# Altium schematic sheet Sheet1.SchDoc(Sheet1)
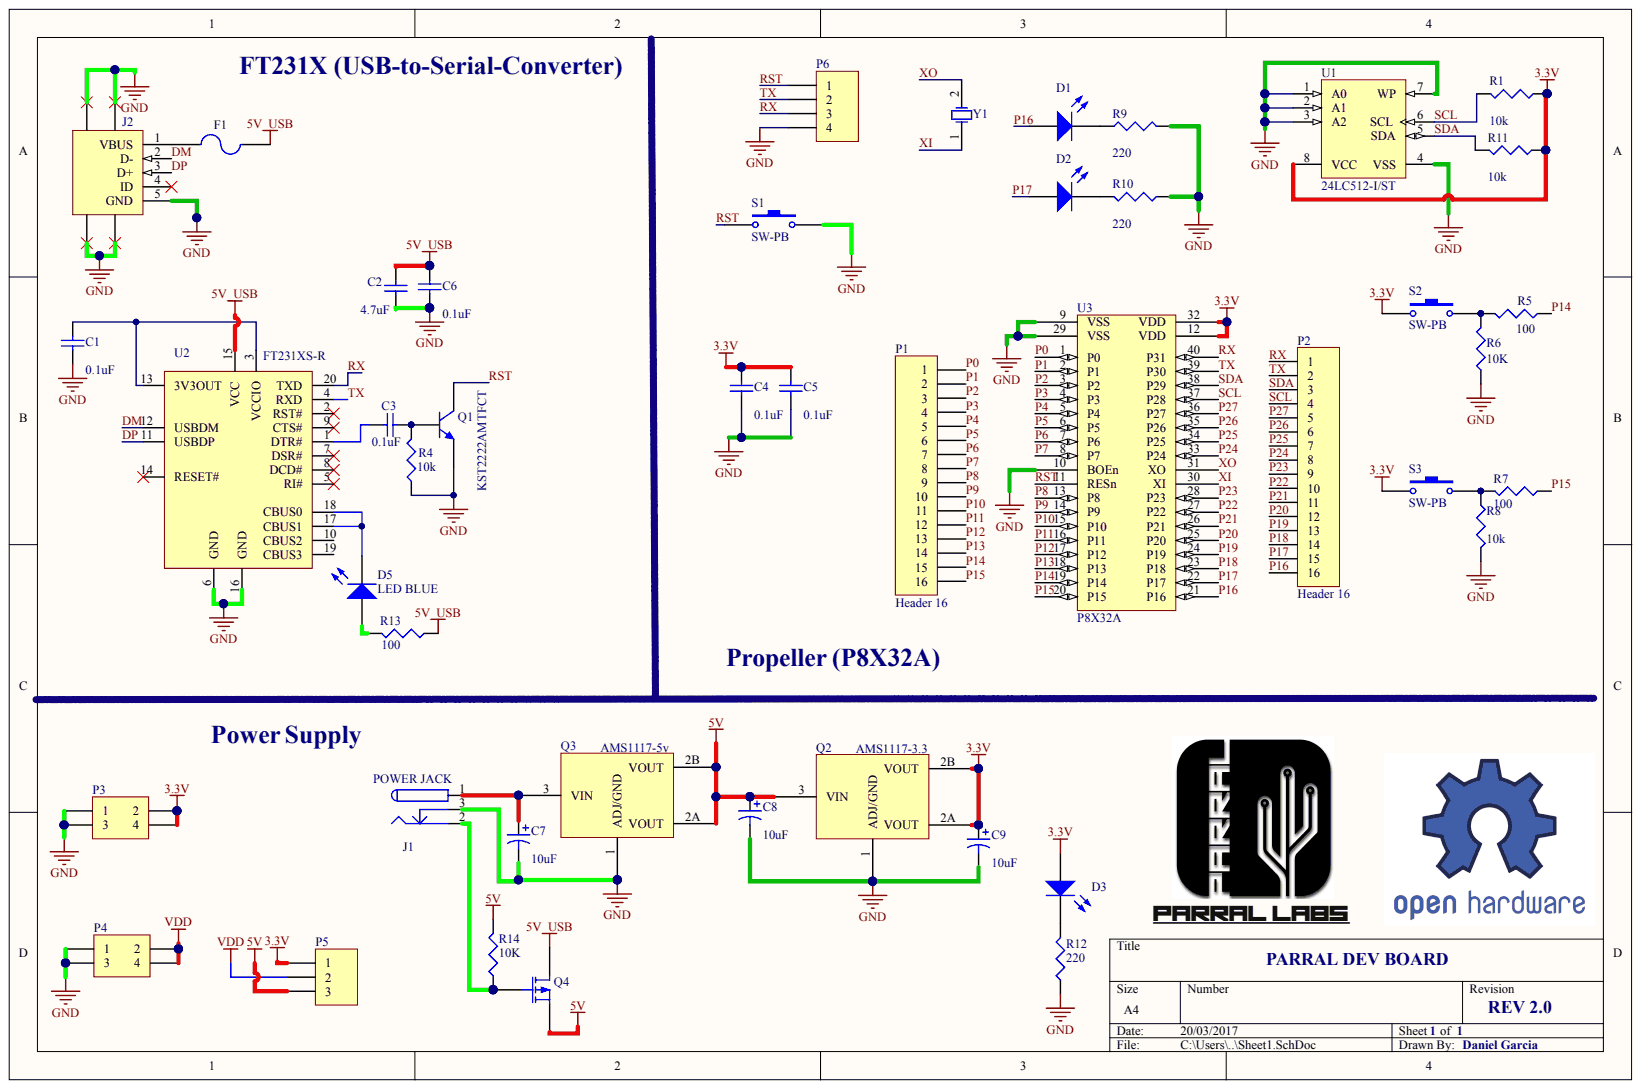
[diagram p0: Sheet1.SchDoc]

## Parts
C1  0.1uF  [0603 - Old1]
C2  4.7uF  [0603 - Old1]
C3  0.1uF  [0603 - Old1]
C4  0.1uF  [0603 - Old1]
C5  0.1uF  [0603 - Old1]
C6  0.1uF  [0603 - Old1]
C7  10uF  [C0805]
C8  10uF  [C0805]
C9  10uF  [C0805]
D1  LED GREEN  [LED-0603]  (value not drawn)
D2  LED BLUE  [LED-0603]  (value not drawn)
D3  LED RED  [LED-0603]  (value not drawn)
D5  LED BLUE  [LED-0603]
F1  PTC  [MF-MSMF050-2]  (value not drawn)
J1  POWER JACK  [PWR JACK]
J2  USB - micro B  [micro B USB 2.0]  (value not drawn)
P1  Header 16  [HDR1X16]
P2  Header 16  [HDR1X16]
P3  Header 2X2  [HDR2X2]  (value not drawn)
P4  Header 2X2  [HDR2X2]  (value not drawn)
P5  Header 3  [HDR1X3]  (value not drawn)
P6  Header 4  [HDR1X4]  (value not drawn)
Q1  KST2222AMTFCT  [SOT-23B_N]
Q2  AMS1117-3.3  Voltage - Output: 3.3V  [SOT223_M]
Q3  AMS1117-5v  Voltage - Output: 5V  [SOT223_M]
Q4  ZXMP6A13FTA  [SOT-23-3]  (value not drawn)
R1  10K  [0603-Resistor]  (value not drawn)
R4  10K  [0603-Resistor]
R5  100  [0603-Resistor]
R6  10K  [0603-Resistor]
R7  100  [0603-Resistor]
R8  10K  [0603-Resistor]
R9  220  [0603-Resistor]
R10  220  [0603-Resistor]
R11  10K  [0603-Resistor]
R12  220  [0603-Resistor]
R13  100  [0603-Resistor]
R14  10K  [0603-Resistor]
S1  SW-PB  [PTS810]
S2  SW-PB  [PTS810]
S3  SW-PB  [PTS810]
U1  24LC512-I/ST  [SOIC-8]
U2  FT231XS-R
U3  P8X32A  [DIP-40]
Y1  XTAL 5MHZ  [XTAL-2]  (value not drawn)

## Nets
3.3V: C4.2 C5.2 C9.1 D3.1 P3.2 P3.4 P5.1 Q2.2A(VOUT) Q2.2B(VOUT) R1.1 R11.1 S2.1 S3.1 U1.8(VCC) U3.12(VDD) U3.32(VDD)
5V: C8.1 P5.3 Q2.3(VIN) Q3.2A(VOUT) Q3.2B(VOUT) Q4.2 R14.2
5V_USB: C2.2 C6.2 F1.2 Q4.3 R13.1 U2.15(VCC)
GND: C1.1 C2.1 C4.1 C5.1 C6.1 C7.2 C8.2 C9.2 J1.3 J2.5(GND) J2.6 J2.7 J2.8 J2.9 P3.1 P3.3 P4.1 P4.3 P6.4 Q1.2 Q2.1(ADJ/GND) Q3.1(ADJ/GND) R4.1 R6.1 R8.2 R9.2 R10.1 R12.1 S1.2 U1.1(A0) U1.2(A1) U1.3(A2) U1.4(VSS) U1.7(WP) U2.6(GND) U2.16(GND) U3.9(VSS) U3.10(BOEn) U3.29(VSS)
VDD: P4.2 P4.4 P5.2
DM: J2.2(D-) U2.12(USBDM)
DP: J2.3(D+) U2.11(USBDP)
P0: P1.1 U3.1(P0)
P1: P1.2 U3.2(P1)
P2: P1.3 U3.3(P2)
P3: P1.4 U3.4(P3)
P4: P1.5 U3.5(P4)
P5: P1.6 U3.6(P5)
P6: P1.7 U3.7(P6)
P7: P1.8 U3.8(P7)
P8: P1.9 U3.13(P8)
P9: P1.10 U3.14(P9)
P10: P1.11 U3.15(P10)
P11: P1.12 U3.16(P11)
P12: P1.13 U3.17(P12)
P13: P1.14 U3.18(P13)
P14: P1.15 R5.1 U3.19(P14)
P15: P1.16 R7.1 U3.20(P15)
P16: D1.1 P2.16 U3.21(P16)
P17: D2.1 P2.15 U3.22(P17)
P18: P2.14 U3.23(P18)
P19: P2.13 U3.24(P19)
P20: P2.12 U3.25(P20)
P21: P2.11 U3.26(P21)
P22: P2.10 U3.27(P22)
P23: P2.9 U3.28(P23)
P24: P2.8 U3.33(P24)
P25: P2.7 U3.34(P25)
P26: P2.6 U3.35(P26)
P27: P2.5 U3.36(P27)
RST: P6.1 Q1.3 S1.1 U3.11(RESn)
RX: P2.1 P6.3 U2.20(TXD) U3.40(P31)
SCL: P2.4 R1.2 U1.6(SCL) U3.37(P28)
SDA: P2.3 R11.2 U1.5(SDA) U3.38(P29)
TX: P2.2 P6.2 U2.4(RXD) U3.39(P30)
XI: U3.30(XI) Y1.1
XO: U3.31(XO) Y1.2
NetC1_2: C1.2 U2.3(VCCIO) U2.13(3V3OUT)
NetC3_1: C3.1 U2.1(DTR#)
NetC3_2: C3.2 Q1.1 R4.2
NetC7_1: C7.1 J1.1 Q3.3(VIN)
NetD1_2: D1.2 R9.1
NetD2_2: D2.2 R10.2
NetD3_2: D3.2 R12.2
NetD5_1: D5.1 R13.2
NetD5_2: D5.2 U2.17(CBUS1) U2.18(CBUS0)
NetF1_1: F1.1 J2.1(VBUS)
NetJ1_2: J1.2 Q4.1 R14.1
NetR5_2: R5.2 R6.2 S2.2
NetR7_2: R7.2 R8.1 S3.2

Not connected: J2 4(ID); U2 2(RST#) 5(RI#) 7(DSR#) 8(DCD#) 9(CTS#) 10(CBUS2) 14(RESET#) 19(CBUS3)

## Library data of the parts
C1 C3 C4 C6 = Cap Semi: 0.1uF Capacitor 0603; PartNumber: 478-1395-1-ND; Component Kind: Standard; LatestRevisionDate: 29-May-2009; LatestRevisionNote: IPC-7351 Footprint Added.; Library Name: Miscellaneous Devices.IntLib; PackageDescription: Chip Capacitor; PackageReference: 0603; Published: 8-Jun-2000; Signal Integrity: Cap Semi; Simulation: CAP; Value: 0.1uF; Publisher: Altium Limited
C2 = Cap Semi: 4.7µF 25V Ceramic Capacitor X5R 0603; ManufacturerPartNumber: CL21A475KAQNNNE; Component Kind: Standard; LatestRevisionDate: 29-May-2009; LatestRevisionNote: IPC-7351 Footprint Added.; Library Name: Miscellaneous Devices.IntLib; PackageDescription: Chip Capacitor; PackageReference: 0603; Published: 8-Jun-2000; Signal Integrity: Cap Semi; Simulation: CAP; Value: 4.7uF; Publisher: Altium Limited
C5 = Cap Semi: 0.1uF Capacitor 0603; Partnumber: 478-1395-1-ND; Component Kind: Standard; LatestRevisionDate: 29-May-2009; LatestRevisionNote: IPC-7351 Footprint Added.; Library Name: Miscellaneous Devices.IntLib; PackageDescription: Chip Capacitor; PackageReference: 0603; Published: 8-Jun-2000; Signal Integrity: Cap Semi; Simulation: CAP; Value: 0.1uF; Publisher: Altium Limited
C7-C9 = Cap Pol3: 10µF Molded Tantalum Capacitors 10V 0805; PartNumber: 399-3684-1-ND; Component Kind: Standard; LatestRevisionDate: 29-May-2009; LatestRevisionNote: IPC-7351 Footprint Added.; Library Name: Miscellaneous Devices.IntLib; PackageDescription: Chip Capacitor; PackageReference: 0805; Published: 8-Jun-2000; Signal Integrity: Cap Pol; Simulation: CAP; Value: 10uF; Publisher: Altium Limited
D1 D3 = LED2: LED 0603; Component Kind: Standard; LatestRevisionDate: 17-Jul-2002; LatestRevisionNote: Re-released for DXP Platform.; Library Name: Miscellaneous Devices.IntLib; PackageDescription: SM LED; 2 Flat Leads; PackageReference: 3.2X1.6X1.1; PackageVersion: Feb-2002; Published: 8-Jun-2000; Simulation: LED2; Publisher: Altium Limited
D2 D5 = LED0: LED 0603; Component Kind: Standard; LatestRevisionDate: 08-Jul-2005; LatestRevisionNote: Mech Layer 1 removed.; Library Name: Miscellaneous Devices.IntLib; PackageDescription: LED; 2 Leads; PackageReference: LED-0; Published: 8-Jun-2000; Simulation: LED0; Publisher: Altium Limited
F1 = Fuse 2: PTC RESETTABLE .50A 15V 1812; Manufacturer: Bourns Inc.; Current - Hold (Ih) (Max): 500mA; Current - Trip (It): 1A; Component Kind: Standard; LatestRevisionDate: 17-Jul-2002; LatestRevisionNote: Re-released for DXP Platform.; Library Name: Miscellaneous Devices.IntLib; Manufacturer Part Number: MF-MSMF050-2; Package / Case: 1812 (4532 Metric), Concave; PackageDescription: Thru-Hole; 2 Leads; Published: 8-Jun-2000; Simulation: FUSE; Voltage - Max: 15V; Publisher: Altium Limited
J1 = Component_1: POWER JACK 5.5x2.1mm; Component Kind: Standard; Library Name: dc-powerjack.SchLib
J2 = USB MICRO B RECPT SMT: CONN USB MICRO B RECPT SMT R/A; Manufacturer: Amphenol FCI; Manufacturer Part Number: 10118192-0001LF; PartNumber: 609-4613-1-ND; Component Kind: Standard; Library Name: MICRO-USB.SchLib; Mounting Type: Surface Mount, Right Angle
P1 P2 = Header 16: Header, 16-Pin; Component Kind: Standard; LatestRevisionDate: 17-Jul-2002; LatestRevisionNote: Re-released for DXP Platform.; Library Name: Miscellaneous Connectors.IntLib; Signal Integrity: Connector; Publisher: Altium Limited
P3 P4 = Header 2X2: Header, 2-Pin, Dual row; Component Kind: Standard; LatestRevisionDate: 17-Jul-2002; LatestRevisionNote: Re-released for DXP Platform.; Library Name: Miscellaneous Connectors.IntLib; Signal Integrity: Connector; Publisher: Altium Limited
P5 = Header 3: Header, 3-Pin; Component Kind: Standard; LatestRevisionDate: 17-Jul-2002; LatestRevisionNote: Re-released for DXP Platform.; Library Name: Miscellaneous Connectors.IntLib; Signal Integrity: Connector; Publisher: Altium Limited
P6 = Header 4: Header, 4-Pin; Component Kind: Standard; LatestRevisionDate: 17-Jul-2002; LatestRevisionNote: Re-released for DXP Platform.; Library Name: Miscellaneous Connectors.IntLib; Signal Integrity: Connector; Publisher: Altium Limited
Q1 = QNPN: TRANS NPN 40V 0.6A SOT-23; Manufacturer: Fairchild/ON Semiconductor; Component Kind: Standard; LatestRevisionDate: 29-May-2009; LatestRevisionNote: IPC-7351 Footprint Added.; Library Name: Miscellaneous Devices.SchLib; Manufacturer Part Number: KST2222AMTF; PackageDescription: 3-Pin SOT-23 Package 0.95 mm Pitch, 2.4 mm Lead Span; PackageReference: SOT-23-3; PackageVersion: Aug-1999; Published: 8-Jun-2000; Signal Integrity: NPN; Simulation: QNPN; Transistor Type: NPN; Publisher: Altium Limited
Q2 = AP1117E33GDICT-ND: Linear Voltage Regulator IC Positive Fixed 1 Output 3.3V; Manufacturer: Advanced Monolithic Systems; Current: 1A; Component Kind: Standard; Library Name: AS1117.SchLib; Manufacturer Part Number: AMS1117-3.3; Mounting Type: Surface Mount; Package: SOT-223-3
Q3 = AP1117E33GDICT-ND: Linear Voltage Regulator IC Positive Fixed 1 Output 3.3V; Manufacturer: Advanced Monolithic Systems; Manufacturer Part Number: AMS1117-5.0; Current: 1A; Component Kind: Standard; Library Name: AS1117.SchLib; Mounting Type: Surface Mount; Package: SOT-223-3
Q4 = MOSFET-P: MOSFET P-CH 60V 0.9A SOT23-3; Component Kind: Standard; Digi-Key Part Numbe: ZXMP6A13FCT-ND; LatestRevisionDate: 17-Jul-2002; LatestRevisionNote: Re-released for DXP Platform.; Library Name: Miscellaneous Devices.SchLib; PackageDescription: TO, Flat Index; 3 In-Line, Axial Leads; Body Dia. 4.6mm; Lead Dia. 0.5 mm (max); PackageReference: E3; PackageVersion: 1995/1996; Published: 8-Jun-2000; Simulation: PMOS; Supplier Device Package: SOT-23-3; Publisher: Altium Limited
R1 R4 R8 R11 R14 = Res3: RES SMD 10K OHM 5% 1/4W 0603; Component Kind: Standard; LatestRevisionDate: 29-May-2009; LatestRevisionNote: IPC-7351 Footprint Added.; Library Name: Miscellaneous Devices.IntLib; PackageDescription: Chip Resistor; PackageReference: J1-0603; Published: 8-Jun-2000; Signal Integrity: Res; Simulation: RESISTOR; Publisher: Altium Limited
  R1 R4 R8 R11: Value: 10k
  R14: Value: 10K
R5 = Res3: RES SMD 100 OHM 5% 1/4W 0603; PartNumber: RMCF1206JT100RCT-ND; Component Kind: Standard; LatestRevisionDate: 29-May-2009; LatestRevisionNote: IPC-7351 Footprint Added.; Library Name: Miscellaneous Devices.IntLib; PackageDescription: Chip Resistor; PackageReference: J1-0603; Published: 8-Jun-2000; Signal Integrity: Res; Simulation: RESISTOR; Value: 100; Publisher: Altium Limited
R6 = Res3: RES SMD 10K OHM 5% 1/4W 0603; PartNumber: 311-10KERCT-ND; Component Kind: Standard; LatestRevisionDate: 29-May-2009; LatestRevisionNote: IPC-7351 Footprint Added.; Library Name: Miscellaneous Devices.IntLib; PackageDescription: Chip Resistor; PackageReference: J1-0603; Published: 8-Jun-2000; Signal Integrity: Res; Simulation: RESISTOR; Value: 10k; Publisher: Altium Limited
R7 R13 = Res3: RES SMD 100 OHM 5% 1/4W 0603; Component Kind: Standard; LatestRevisionDate: 29-May-2009; LatestRevisionNote: IPC-7351 Footprint Added.; Library Name: Miscellaneous Devices.IntLib; PackageDescription: Chip Resistor; PackageReference: J1-0603; Published: 8-Jun-2000; Signal Integrity: Res; Simulation: RESISTOR; Value: 100; Publisher: Altium Limited
R9 R10 = Res3: RES SMD 220 OHM 5% 1/4W 0603; Component Kind: Standard; LatestRevisionDate: 29-May-2009; LatestRevisionNote: IPC-7351 Footprint Added.; Library Name: Miscellaneous Devices.IntLib; PackageDescription: Chip Resistor; PackageReference: J1-0603; Published: 8-Jun-2000; Signal Integrity: Res; Simulation: RESISTOR; Value: 220; Publisher: Altium Limited
R12 = Res3: RES SMD 220 OHM 5% 1/4W 0603; PartNumber: er  P220ECT-ND; Component Kind: Standard; LatestRevisionDate: 29-May-2009; LatestRevisionNote: IPC-7351 Footprint Added.; Library Name: Miscellaneous Devices.IntLib; PackageDescription: Chip Resistor; PackageReference: J1-0603; Published: 8-Jun-2000; Signal Integrity: Res; Simulation: RESISTOR; Value: 220; Publisher: Altium Limited
S1-S3 = SW-PB: SWITCH TACTILE SPST-NO 0.05A 12V; Manufacturer: Omron Electronics Inc-EMC Div; Manufacturer Part Number: B3U-1000P; Component Kind: Standard; LatestRevisionDate: 17-Jul-2002; LatestRevisionNote: Re-released for DXP Platform.; Library Name: Miscellaneous Devices.IntLib; PackageDescription: Switch; 2 Leads; PackageReference: SPST-2; Published: 24-Mar-1999; Publisher: Altium Limited
U1 = 24LC256-I/ST: IC EEPROM 512KBIT 400KHZ 8SOIJ; Manufacturer Part Number: 24LC512-I/ST-ND; Code_JEDEC: MO-153; Component Kind: Standard; ComponentLink1Description: Datasheet; ComponentLink1URL: http://ww1.microchip.com/downloads/en/DeviceDoc/21203P.pdf; ComponentLink2Description: Packaging Specification; ComponentLink2URL: http://www.microchip.com/stellent/groups/techpub_sg/documents/packagingspec/en012702.pdf; ComponentLink3Description: Microchip.com Home; ComponentLink3URL: http://www.microchip.com/; DatasheetVersion: revP, Oct-2007; Library Name: Microchip Memory I2C Serial EEPROM.IntLib; PackageDescription: 8-Lead Plastic Thin Shrink Small Outline (ST) - 4.4mm Body [TSSOP]; PackageReference: TSSOP-ST8; PackageVersion: revBB, Aug-2009; Published: 29-Jan-2009; Supplier 1: Digi-Key; Supplier 2: Farnell; Supplier Part Number 2: 1296579; Publisher: Altium Limited
U2 = FT231XS-R: IC USB SERIAL FULL UART 20SSOP; Manufacturer: FTDI, Future Technology Devices International Ltd; Categories: Integrated Circuits (ICs)   Interface - Controllers; Voltage - Supply: 3.3 V ~ 5 V; Component Kind: Standard; Digi-Key Part Number: 768-1129-1-ND; Interface: UART; Library Name: FT231XS.SchLib; Operating Temperature: -40°C ~ 85°C; Package / Case: 20-SSOP (0.154", 3.90mm Width); Part Number: FT231XS-R
U3 = P8X32A-D40: Propeller™ Microcontroller IC 32-Bit 80MHz 32KB (32K x 8) ROM; Manufacturer: PARALLAX INC; Component Kind: Standard; DigiKey PN: P8X32A-D40-ND; Family: Embedded - Microcontrollers; Manufacturer Part Number: P8X32A-D40; Package / Case: 40-DIP; Speed: 80MHz; Library Name: Custon component.SchLib
Y1 = XTAL: CRYSTAL 5.0000MHZ 18PF T/H; Manufacturer: Citizen Finedevice Co Ltd; Partnumber: 300-6009-ND; Component Kind: Standard; Frequency: 5MHz; LatestRevisionDate: 17-Jul-2002; LatestRevisionNote: Re-released for DXP Platform.; Library Name: Miscellaneous Devices.IntLib; Package: HC49/US; PackageDescription: Crystal, Thru-Hole; 2 Leads; Body 3.1 x 8.2 mm (Dia.xH); PackageReference: R38; Published: 8-Jun-2000; Simulation: XTAL; Type: MHz Crystal; Publisher: Altium Limited
